# Altium schematic sheet InterfaceComUsuario.SchDoc
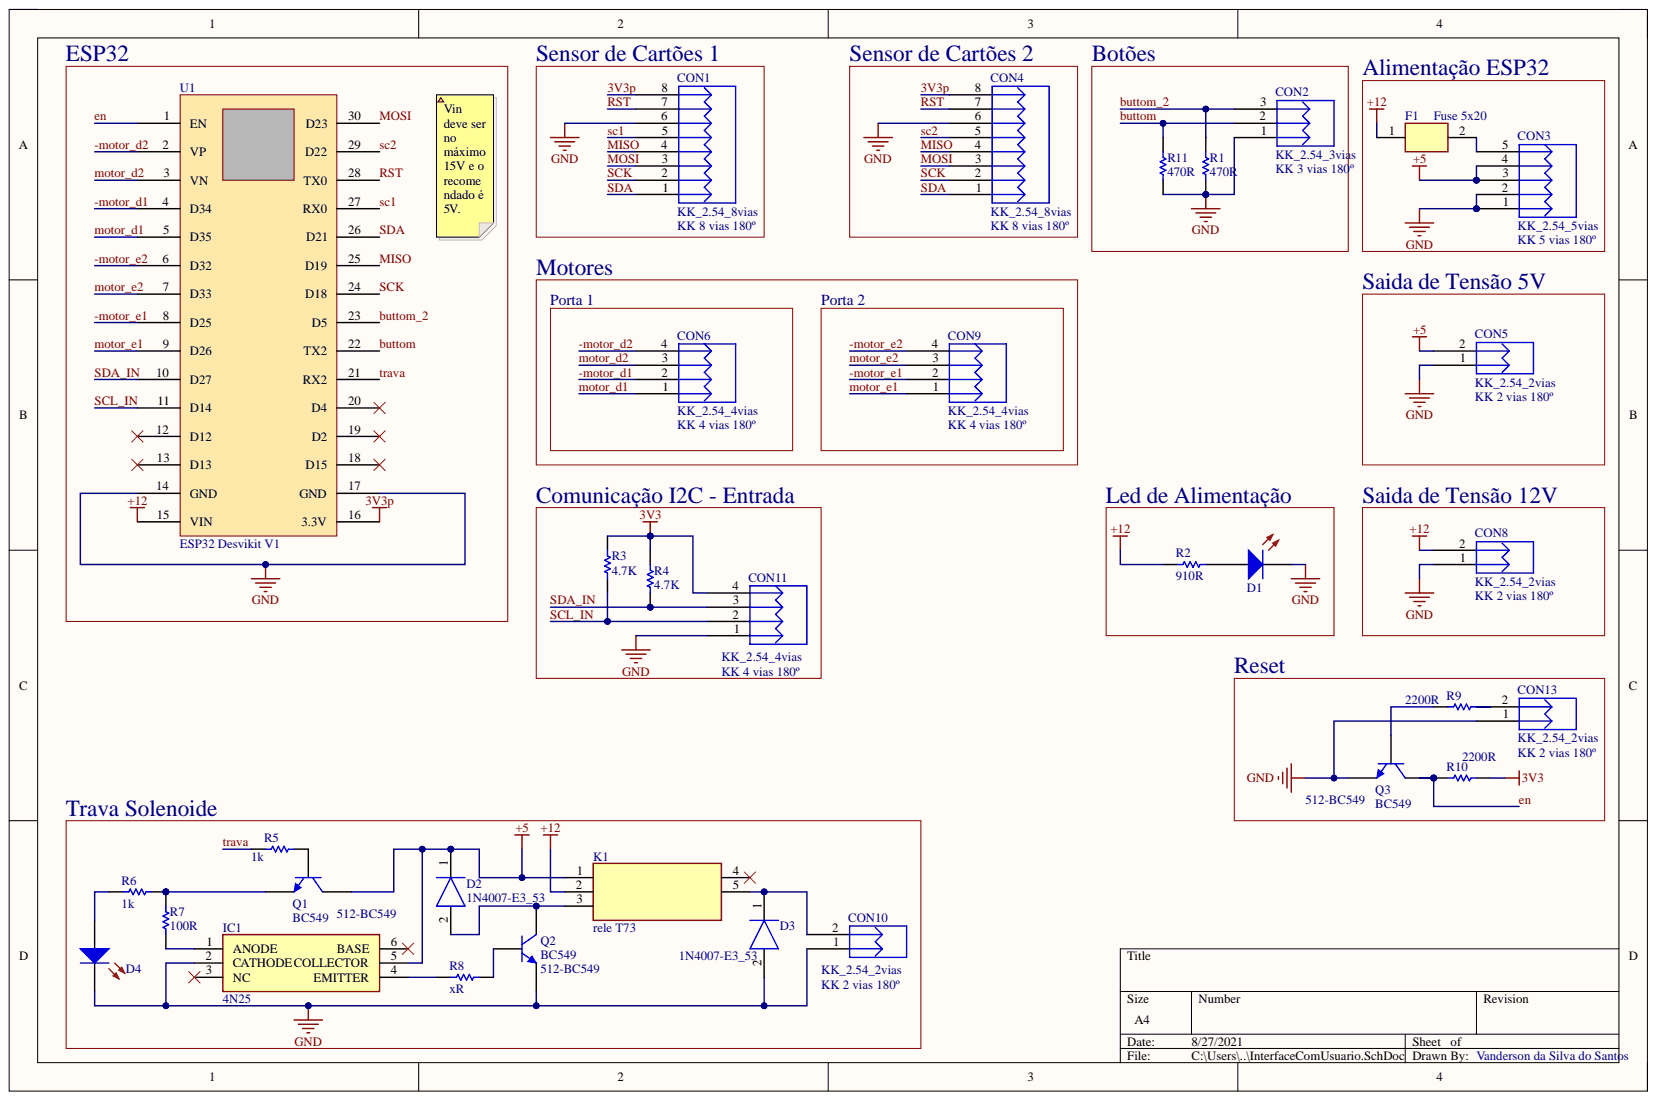
[diagram p0: InterfaceComUsuario.SchDoc]

## Parts
CON1  KK_2.54_8vias  Valor: KK 8 vias 180º  [KK_8vias_180°]
CON2  KK_2.54_3vias  Valor: KK 3 vias 180º  [KK_3vias_180º]
CON3  KK_2.54_5vias  Valor: KK 5 vias 180º  [KK_5vias_180°]
CON4  KK_2.54_8vias  Valor: KK 8 vias 180º  [KK_8vias_180°]
CON5  KK_2.54_2vias  Valor: KK 2 vias 180º  [KK_2VIAS_180º]
CON6  KK_2.54_4vias  Valor: KK 4 vias 180º  [KK_4vias_180°]
CON8  KK_2.54_2vias  Valor: KK 2 vias 180º  [KK_2VIAS_180º]
CON9  KK_2.54_4vias  Valor: KK 4 vias 180º  [KK_4vias_180°]
CON10  KK_2.54_2vias  Valor: KK 2 vias 180º  [KK_2VIAS_180º]
CON11  KK_2.54_4vias  Valor: KK 4 vias 180º  [KK_4vias_180°]
CON13  KK_2.54_2vias  Valor: KK 2 vias 180º  [KK_2VIAS_180º]
D1  LED 5MM RED  [LED 5MM RED]  (value not drawn)
D2  1N4007-E3_53  [DIOAD1405W89L465D235]
D3  1N4007-E3_53  [DIOAD1405W89L465D235]
D4  LED 5MM RED  [LED 5MM RED]  (value not drawn)
F1  Fuse 5x20  [Fuse 5x20]
IC1  4N25  [DIP762W50P254L712H450Q6N]
K1  rele T73  [JQC3FT731Z12VDC]
Q1  BC549  Supplier Part Number 1: 512-BC549  [TO92]
Q2  BC549  Supplier Part Number 1: 512-BC549  [TO92]
Q3  BC549  Supplier Part Number 1: 512-BC549  [TO92]
R1  Resistance (Ohms): 470R  [RES 470R 1/4W CARBON FILM]  (hidden comment: RES 470R 1/4W CARBON FILM)
R2  Resistance (Ohms): 910R  [RES 470R 1/4W CARBON FILM]  (hidden comment: RES 470R 1/4W CARBON FILM)
R3  4.7K  [RES 4.7K 1/4W CARBON FILM]
R4  4.7K  [RES 4.7K 1/4W CARBON FILM]
R5  Resistance (Ohms): 1k  [RES 470R 1/4W CARBON FILM]  (hidden comment: RES 470R 1/4W CARBON FILM)
R6  Resistance (Ohms): 1k  [RES 470R 1/4W CARBON FILM]  (hidden comment: RES 470R 1/4W CARBON FILM)
R7  Resistance (Ohms): 100R  [RES 470R 1/4W CARBON FILM]  (hidden comment: RES 470R 1/4W CARBON FILM)
R8  Resistance (Ohms): xR  [RES 470R 1/4W CARBON FILM]  (hidden comment: RES 470R 1/4W CARBON FILM)
R9  Resistance (Ohms): 2200R  [RES 470R 1/4W CARBON FILM]  (hidden comment: RES 470R 1/4W CARBON FILM)
R10  Resistance (Ohms): 2200R  [RES 470R 1/4W CARBON FILM]  (hidden comment: RES 470R 1/4W CARBON FILM)
R11  Resistance (Ohms): 470R  [RES 470R 1/4W CARBON FILM]  (hidden comment: RES 470R 1/4W CARBON FILM)
U1  value ESP32 Desvikit V1  [ESP32_Desvikit_v1]  (hidden comment: microcontrolador)

## Nets
3V3: CON11.4 R3.1 R4.1 R10.2
+5: CON3.3 CON3.4 CON5.2 D2.1 IC1.5(COLLECTOR) K1.1 Q1.1
+12: CON8.2 F1.1 K1.2 R2.2 U1.15(VIN)
GND: CON1.6 CON2.1 CON3.1 CON3.2 CON4.6 CON5.1 CON8.1 CON10.1 CON11.1 CON13.1 D1.2 D3.2 D4.2 IC1.2(CATHODE) Q2.3 Q3.3 R1.1 R11.1 U1.14(GND) U1.17(GND)
3V3p: CON1.8 CON4.8 U1.16(3.3V)
-motor_d1: CON6.2 U1.4(D34)
-motor_d2: CON6.4 U1.2(VP)
-motor_e1: CON9.2 U1.8(D25)
-motor_e2: CON9.4 U1.6(D32)
buttom: CON2.2 R11.2 U1.22(TX2)
buttom_2: CON2.3 R1.2 U1.23(D5)
en: Q3.1 R10.1 U1.1(EN)
MISO: CON1.4 CON4.4 U1.25(D19)
MOSI: CON1.3 CON4.3 U1.30(D23)
motor_d1: CON6.1 U1.5(D35)
motor_d2: CON6.3 U1.3(VN)
motor_e1: CON9.1 U1.9(D26)
motor_e2: CON9.3 U1.7(D33)
RST: CON1.7 CON4.7 U1.28(TX0)
sc1: CON1.5 U1.27(RX0)
sc2: CON4.5 U1.29(D22)
SCK: CON1.2 CON4.2 U1.24(D18)
SCL_IN: CON11.2 R3.2 U1.11(D14)
SDA: CON1.1 CON4.1 U1.26(D21)
SDA_IN: CON11.3 R4.2 U1.10(D27)
trava: R5.1 U1.21(RX2)
NetCON3_5: CON3.5 F1.2
NetCON10_2: CON10.2 D3.1 K1.5
NetCON13_2: CON13.2 R9.2
NetD1_1: D1.1 R2.1
NetD2_2: D2.2 K1.3 Q2.1
NetD4_1: D4.1 R6.2
NetIC1_1: IC1.1(ANODE) R7.1
NetIC1_4: IC1.4(EMITTER) R8.2
NetQ1_2: Q1.2 R5.2
NetQ1_3: Q1.3 R6.1 R7.2
NetQ2_2: Q2.2 R8.1
NetQ3_2: Q3.2 R9.1

Not connected: IC1 3(NC) 6(BASE); K1 4; U1 12(D12) 13(D13) 18(D15) 19(D2) 20(D4)

## Library data of the parts
CON1 CON4 = KK_2.54_8vias: Conector KK 2.54mm 8 vias; Descrição: Conector KK 3 vias com passo 2.54mm; Encapsulamento: PTH - 180º; Modelo: 3 vias - 180º; Component Kind: Standard; Library Name: Conectores_Sch.SchLib
CON2 = KK_2.54_3vias: Conector KK 2.54mm 3 vias; Descrição: Conector KK 3 vias com passo 2.54mm; Encapsulamento: PTH - 180º; Modelo: 3 vias - 180º; Component Kind: Standard; Library Name: Conectores_Sch.SchLib
CON3 = KK_2.54_5vias: Conector KK 2.54mm 5 vias; Descrição: Conector KK 3 vias com passo 2.54mm; Encapsulamento: PTH - 180º; Modelo: 3 vias - 180º; Component Kind: Standard; Library Name: Conectores_Sch.SchLib
CON5 CON8 CON10 CON13 = KK_2.54_2vias: Conector KK 2.54mm 2 vias; Descrição: Conector KK 3 vias com passo 2.54mm; Encapsulamento: PTH - 180º; Modelo: 2 vias - 180º; Component Kind: Standard; Library Name: Conectores_Sch.SchLib
CON6 CON9 CON11 = KK_2.54_4vias: Conector KK 2.54mm 4 vias; Descrição: Conector KK 3 vias com passo 2.54mm; Encapsulamento: PTH - 180º; Modelo: 3 vias - 180º; Component Kind: Standard; Library Name: Conectores_Sch.SchLib
D1 D4 = LED 5MM RED: Manufacturer: Paralight; Manufacturer Part Number: IMP585; Bulb Size: 3mm; Current If Luminous Intensity: 2; Dominant Wavelength: 625; External Diameter: 2.9; External Length / Height: 4.6; Forward Current If: 20; Forward Voltage VF Max: 2.5; Lead Cross-section Depth: 0.5; LED / Lamp Size: 3mm / T-1; LED Mounting: Through Hole; LED Type: Standard; Lens Shape: Round; Luminous Intensity: 3; Luminous Intensity @ If Min: 0.7; Peak Forward Current: 7; PIV Max: 5; SVHC: No SVHC (15-Jun-2015); Viewing Angle: 60°; Voltage Vf Typ: 2.2V<!--FANDB--><div class="features-and-benefits-container"><div class="features-and-benefits-description"><span class="features-benefits-value">The L-934LID from Kingbright is a through hole round shape high efficiency red colour LED. The device is made of gallium arsenide phosphide on gallium phosphide orange light emitting diode. This LED is widely used because of low power consumption, long life and solid state reliability.</span></div><div class="features-and-benefits-list"><ul class="features-and-benefits-list-list"><li class="features-and-benefits-list-item">Standard bulb size of T-1 (3mm)</li><li class="features-and-benefits-list-item">Reliable and rugged</li><li class="features-and-benefits-list-item">Viewing angle is 60°</li><li class="features-and-benefits-list-item">Luminous intensity of 3mcd at 2mA</li><li class="features-and-benefits-list-item">Forward voltage is 2.0V</li><li class="features-and-benefits-list-item">Forward current (If) is 20mA</li><li class="features-and-benefits-list-item">Dominant wavelength is 625nm at IF=20mA</li><li class="features-and-benefits-list-item">Peak wavelength is 627nm at IF=20; Component Kind: Standard; ComponentLink1Description: http://loja.multcomercial.com.br/led-3mm-vermelho-difuso-l-314hd-paralight.html; ComponentLink1URL: http://loja.multcomercial.com.br/led-3mm-vermelho-difuso-l-314hd-paralight.html; Description: LED 5MM RED; Forward Current If Max: 20; Forward Voltage: 2; Lead Cross-section Width: 0.5; Lead Spacing: 2.54; LED Colour: Red; Library Name: esp32.SchLib; Luminous Intensity Typ: 3; Mounting Type: PCB Through Hole; MSL: -; No. of Pins: 2; Operating Temperature Max: 85; Operating Temperature Min: -40; Operating Temperature Range: -40°C to +85; Packaging: Each; Peak Wavelength: 625; Pricing 1: 1=0.15 (BRL); RoHS: YES; Stock 1: 35260; Supplier 1: Mult Comercial; Supplier Part Number 1: Led 3mm Vermelho Difuso L-314HD - Paralight; Wavelength Typ: 625
D2 D3 = 1N4007-E3_53: Diode; Manufacturer_Name: Vishay; Manufacturer_Part_Number: 1N4007-E3/53; Arrow Part Number: 1N4007-E3/53; Arrow Price/Stock: https://www.arrow.com/en/products/1n4007-e353/vishay; Component Kind: Standard; Datasheet Link: http://www.vishay.com/docs/88503/1n4001.pdf; Mouser Part Number: 625-1N4007-E3/53; Mouser Price/Stock: https://www.mouser.co.uk/ProductDetail/Vishay-General-Semiconductor/1N4007-E3-53/?qs=N4vtoAxH%2FSpFgYSIWmQOdg%3D%3D
F1 = Fuse 5x20: Fuse; Manufacturer_Name: QIANJI; Manufacturer_Part_Number: JQC-3F-(T73)-1Z-12VDC; Component Kind: Standard; Datasheet Link: https://img.ozdisan.com/ETicaret_Dosya/341245_8627675.pdf; Height: 15.5mm; Library Name: esp32.SchLib
IC1 = 4N25: Integrated Circuit; Manufacturer_Name: Isocom; Arrow Part Number: 4N25; Arrow Price/Stock: https://www.arrow.com/en/products/4n25/isocom; Component Kind: Standard; Datasheet Link: http://www.farnell.com/datasheets/90919.pdf; Height: 4.5mm; Library Name: esp32.SchLib; Manufacturer_Part_Number: 4N25
K1 = rele T73: Relay or Contactor; Manufacturer_Name: QIANJI; Manufacturer_Part_Number: JQC-3F-(T73)-1Z-12VDC; Component Kind: Standard; Datasheet Link: https://img.ozdisan.com/ETicaret_Dosya/341245_8627675.pdf; Height: 15.5mm; Library Name: esp32.SchLib
Q1-Q3 = BC549: TRANS NPN 30V 0.1A TO-92; Brand: Fairchild Semiconductor; Collector-Emitter Saturation Voltage: 200 mV; Configuration: Single; DC Collector/Base Gain hfe Min: 110; DC Current Gain hFE Max: 800; Emitter- Base Voltage VEBO: 5 V; Gain Bandwidth Product fT: 300 MHz; Maximum Power Dissipation: 0.5 W; Collector- Base Voltage VCBO: 30 V; Collector- Emitter Voltage VCEO Max: 30 V; Component Kind: Standard; ComponentLink1Description: https://datasheet.ciiva.com/982/bc546-982130.pdf; ComponentLink1URL: https://datasheet.ciiva.com/982/bc546-982130.pdf; ComponentLink2Description: https://datasheet.ciiva.com/17179/bc549-17179663.pdf; ComponentLink2URL: https://datasheet.ciiva.com/17179/bc549-17179663.pdf; Factory Pack Quantity: 2000; Manufacturer: Fairchild Semiconductor; Manufacturer Part Number: BC549; Maximum DC Collector Current: 0.1 A; Maximum Operating Temperature: + 150 C; Minimum Operating Temperature: - 65 C; Mounting Style: Through Hole; Package / Case: TO-92-3; Packaging: Bulk; Product Category: Bipolar Transistors - BJT; RoHS: Details; Series: BC549; Supplier 1: Mouser; Transistor Polarity: NPN; Library Name: PS_Balanca.SchLib
R1 R2 R5-R11 = RES 470R 1/4W CARBON FILM: RES 470R OHM 1/4W 5% CARBON FILM; Manufacturer: Ty-Ohm; Manufacturer Part Number: CR25; Power (Watts): 0.25W, 1/4W; Temperature Coefficient: 0/ -500ppm/°C; Category: Resistors; Component Kind: Standard; ComponentLink1Description: http://loja.multcomercial.com.br/resistor-de-1-4-de-watt-cr25-5-de-tolerancia.html; ComponentLink1URL: http://loja.multcomercial.com.br/resistor-de-1-4-de-watt-cr25-5-de-tolerancia.html; Composition: Carbon Film; Features: Flame Retardant Coating, Safety; Height: -; Library Name: esp32.SchLib; Number of Terminations: 2; Operating Temperature: -55°C ~ 155°C; Package / Case: Axial; Packaging: Cut Tape (CT); Pricing 1: 1=0.20 (BRL); RoHS: RoHS Compliant; Size / Dimension: 0.091" Dia x 0.256" L (2.30mm x 6.50mm); Stock 1: 617543; Supplier 1: Mult Comercial; Supplier Device Package: Axial; Supplier Part Number 1: Resistor de Filme de Carbono 1/4 de Watt CR25 5% de tolerância - 0.22R Ω à 10M Ω; Tolerance: ±5%
R3 R4 = RES 4.7K 1/4W CARBON FILM: RES 4.7K OHM 1/4W 5% CARBON FILM; Manufacturer: Ty-Ohm; Manufacturer Part Number: CR25; Power (Watts): 0.25W, 1/4W; Temperature Coefficient: 0/ -500ppm/°C; Category: Resistors; Component Kind: Standard; ComponentLink1Description: http://loja.multcomercial.com.br/resistor-de-1-4-de-watt-cr25-5-de-tolerancia.html; ComponentLink1URL: http://loja.multcomercial.com.br/resistor-de-1-4-de-watt-cr25-5-de-tolerancia.html; Composition: Carbon Film; Features: Flame Retardant Coating, Safety; Height: -; Library Name: esp32.SchLib; Number of Terminations: 2; Operating Temperature: -55°C ~ 155°C; Package / Case: Axial; Packaging: Cut Tape (CT); Pricing 1: 1=0.20 (BRL); Resistance (Ohms): ?; RoHS: RoHS Compliant; Size / Dimension: 0.091" Dia x 0.256" L (2.30mm x 6.50mm); Stock 1: 617543; Supplier 1: Mult Comercial; Supplier Device Package: Axial; Supplier Part Number 1: Resistor de Filme de Carbono 1/4 de Watt CR25 5% de tolerância - 0.22R Ω à 10M Ω; Tolerance: ±5%
U1 = ESP32 Desvikit v1: microcontrolador com moculo bluethoth e wifi; Component Kind: Standard; Library Name: esp32.SchLib
